# Altium schematic sheet PMK_Sch.SchDoc(PMK_Sch)
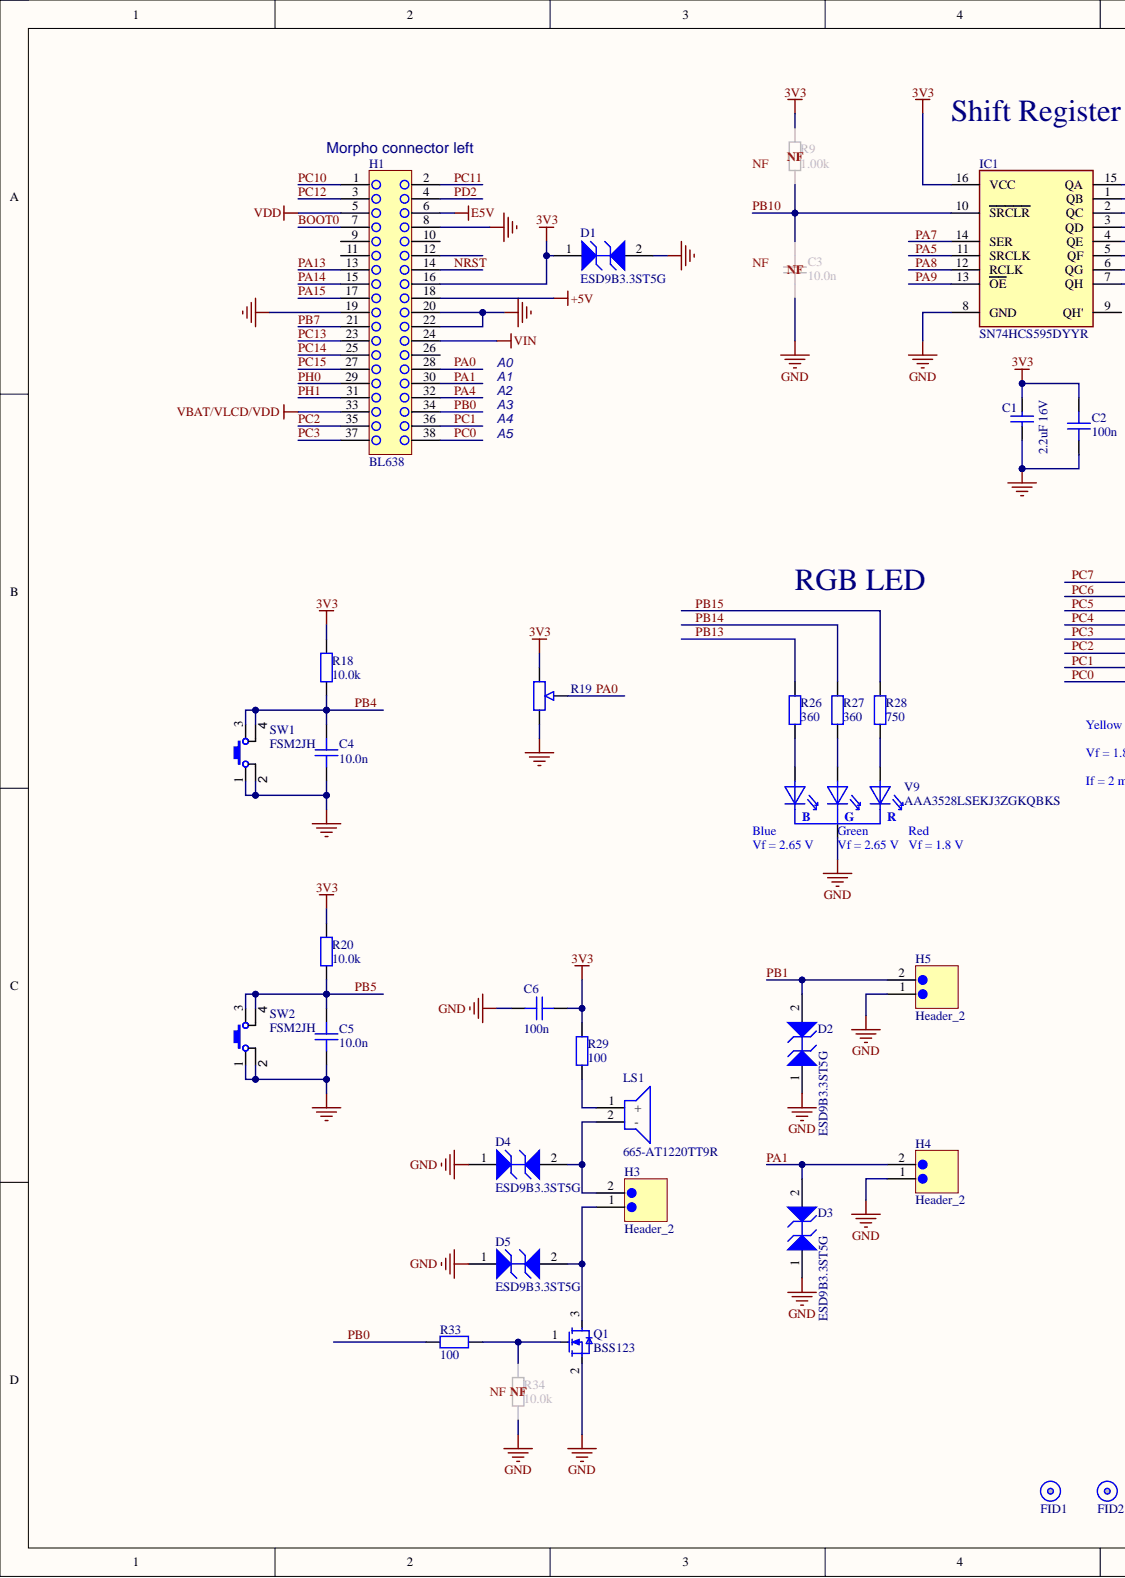
[diagram p0: PMK_Sch.SchDoc, left]
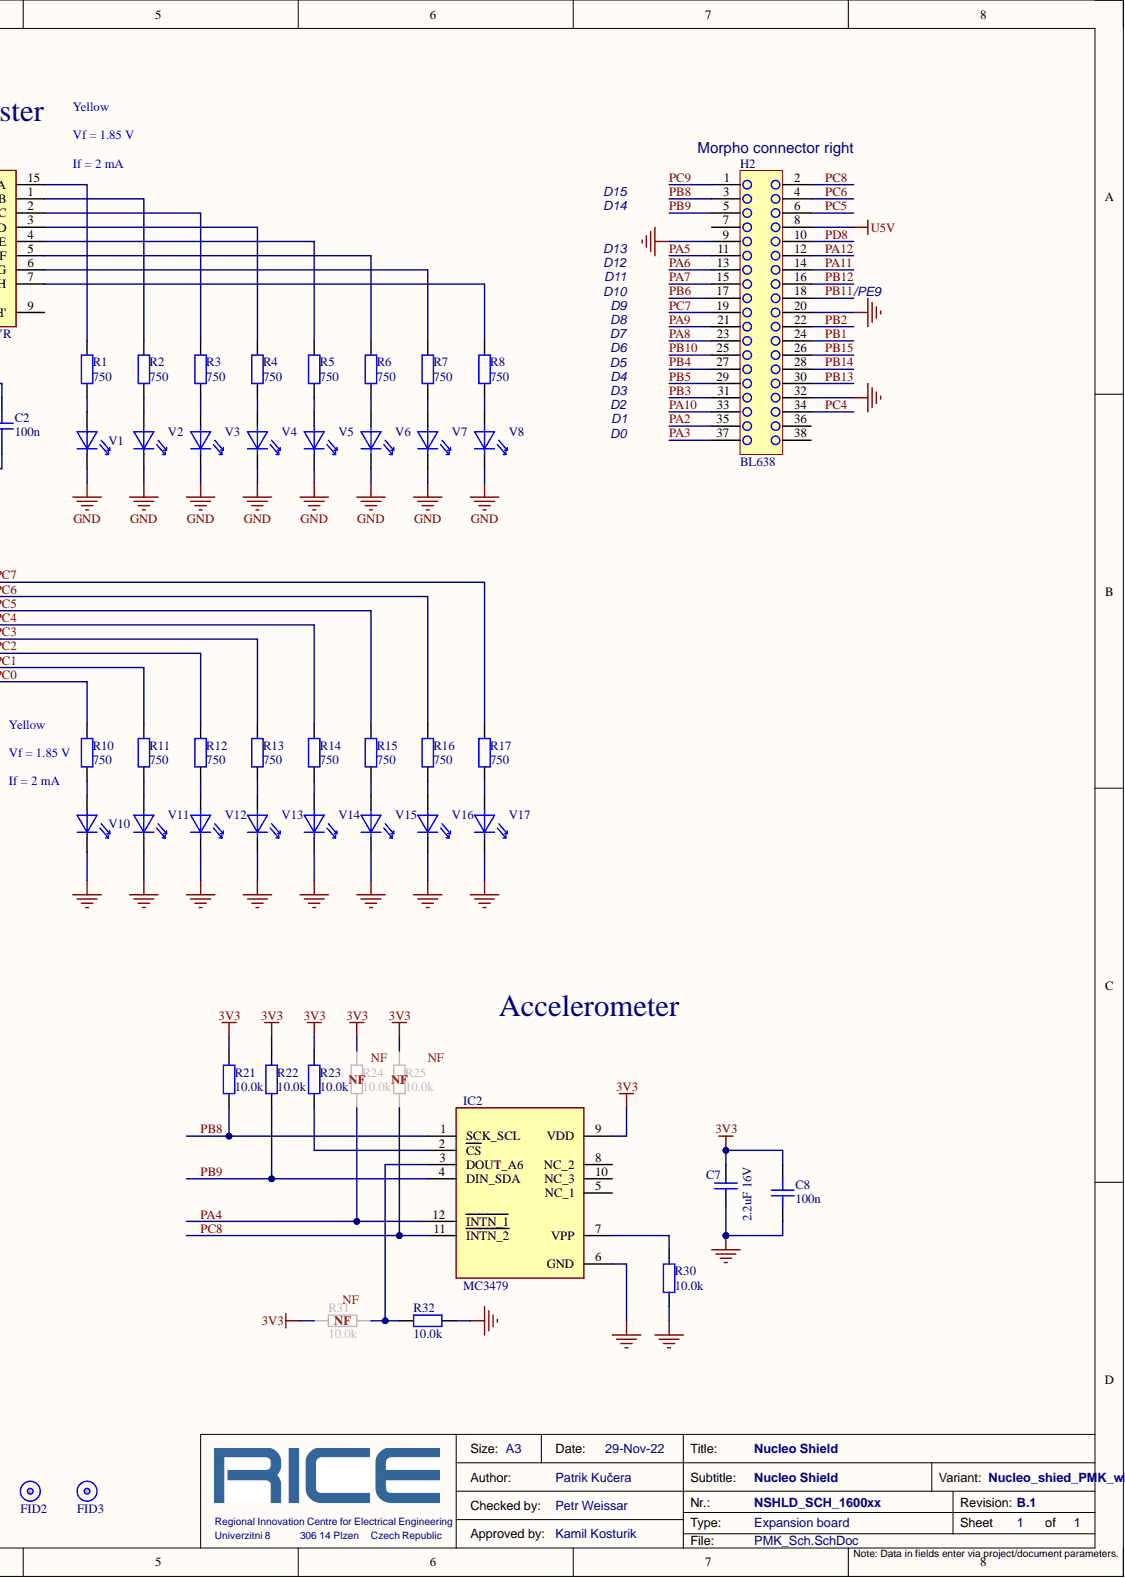
[diagram p1: PMK_Sch.SchDoc, right]

## Parts
C1  2.2uF 16V  [CAPC2013X135X50ML10T20]
C2  100n  [sm_cap_0805]
C3  10.0n  [sm_cap_0805]
C4  10.0n  [sm_cap_0805]
C5  10.0n  [sm_cap_0805]
C6  100n  [sm_cap_0402]
C7  2.2uF 16V  [CAPC2013X135X50ML10T20]
C8  100n  [sm_cap_0805]
D1  ESD9B3.3ST5G  [ONSC-SOD-923-2-514AB-01_V]
D2  ESD9B3.3ST5G  [ONSC-SOD-923-2-514AB-01_V]
D3  ESD9B3.3ST5G  [ONSC-SOD-923-2-514AB-01_V]
D4  ESD9B3.3ST5G  [ONSC-SOD-923-2-514AB-01_V]
D5  ESD9B3.3ST5G  [ONSC-SOD-923-2-514AB-01_V]
FID1  Fiducial  [SM_mch_FIDUCIAL]  (value not drawn)
FID2  Fiducial  [SM_mch_FIDUCIAL]  (value not drawn)
FID3  Fiducial  [SM_mch_FIDUCIAL]  (value not drawn)
H1  BL638  [th_con_rec2x19_pit100]
H2  BL638  [th_con_rec2x19_pit100]
H3  Header_2  [th_con_HDR1X2_pit100]
H4  Header_2  [th_con_HDR1X2_pit100]
H5  Header_2  [th_con_HDR1X2_pit100]
IC1  SN74HCS595DYYR  [SOP65P640X120-16N]
IC2  MC3479  [MC3479]
LS1  AT1220TT9R  Supplier Part Number 1: 665-AT1220TT9R  [AT1220TT9R]
Q1  BSS123  [SM_TRA_SOT23]
R1  750  [sm_res_0805]
R2  750  [sm_res_0805]
R3  750  [sm_res_0805]
R4  750  [sm_res_0805]
R5  750  [sm_res_0805]
R6  750  [sm_res_0805]
R7  750  [sm_res_0805]
R8  750  [sm_res_0805]
R9  1.00k  [sm_res_0805]
R10  750  [sm_res_0805]
R11  750  [sm_res_0805]
R12  750  [sm_res_0805]
R13  750  [sm_res_0805]
R14  750  [sm_res_0805]
R15  750  [sm_res_0805]
R16  750  [sm_res_0805]
R17  750  [sm_res_0805]
R18  10.0k  [sm_res_0805]
R19  10K  [3386F1103TLF]  (value not drawn)
R20  10.0k  [sm_res_0805]
R21  10.0k  [sm_res_0805]
R22  10.0k  [sm_res_0805]
R23  10.0k  [sm_res_0805]
R24  10.0k  [sm_res_0805]
R25  10.0k  [sm_res_0805]
R26  360  [sm_res_0805]
R27  360  [sm_res_0805]
R28  750  [sm_res_0805]
R29  100  [sm_res_0805]
R30  10.0k  [sm_res_0805]
R31  10.0k  [sm_res_0805]
R32  10.0k  [sm_res_0805]
R33  100  [sm_res_0805]
R34  10.0k  [sm_res_0805]
SW1  FSM2JH  [th_swi_lph]
SW2  FSM2JH  [th_swi_lph]
V1  APT2012LSYCK/J3-PRV  [sm_opt_led0805]  (value not drawn)
V2  APT2012LSYCK/J3-PRV  [sm_opt_led0805]  (value not drawn)
V3  APT2012LSYCK/J3-PRV  [sm_opt_led0805]  (value not drawn)
V4  APT2012LSYCK/J3-PRV  [sm_opt_led0805]  (value not drawn)
V5  APT2012LSYCK/J3-PRV  [sm_opt_led0805]  (value not drawn)
V6  APT2012LSYCK/J3-PRV  [sm_opt_led0805]  (value not drawn)
V7  APT2012LSYCK/J3-PRV  [sm_opt_led0805]  (value not drawn)
V8  APT2012LSYCK/J3-PRV  [sm_opt_led0805]  (value not drawn)
V9  AAA3528LSEKJ3ZGKQBKS  [AAA3528LSEKJ3ZGKQBKS-MFG]
V10  APT2012LSYCK/J3-PRV  [sm_opt_led0805]  (value not drawn)
V11  APT2012LSYCK/J3-PRV  [sm_opt_led0805]  (value not drawn)
V12  APT2012LSYCK/J3-PRV  [sm_opt_led0805]  (value not drawn)
V13  APT2012LSYCK/J3-PRV  [sm_opt_led0805]  (value not drawn)
V14  APT2012LSYCK/J3-PRV  [sm_opt_led0805]  (value not drawn)
V15  APT2012LSYCK/J3-PRV  [sm_opt_led0805]  (value not drawn)
V16  APT2012LSYCK/J3-PRV  [sm_opt_led0805]  (value not drawn)
V17  APT2012LSYCK/J3-PRV  [sm_opt_led0805]  (value not drawn)

## Nets
3V3: C1.2 C2.2 C6.2 C7.2 C8.2 D1.1 H1.16 IC1.16(VCC) IC2.9(VDD) R9.1 R18.2 R19.3 R20.2 R21.2 R22.2 R23.2 R24.2 R25.2 R29.2 R31.2
+5V: H1.18
E5V: H1.6
GND: C1.1 C2.1 C3.1 C4.1 C5.1 C6.1 C7.1 C8.1 D1.2 D2.1 D3.1 D4.1 D5.1 H1.8 H1.19 H1.20 H1.22 H2.9 H2.20 H2.32 H4.1 H5.1 IC1.8(GND) IC2.6(GND) Q1.2 R19.1 R30.1 R32.1 R34.1 SW1.1 SW1.2 SW2.1 SW2.2 V1.2 V2.2 V3.2 V4.2 V5.2 V6.2 V7.2 V8.2 V9.2 V10.2 V11.2 V12.2 V13.2 V14.2 V15.2 V16.2 V17.2
U5V: H2.8
VBAT/VLCD/VDD: H1.33
VDD: H1.5
VIN: H1.24
BOOT0: H1.7
NRST: H1.14
PA0: H1.28 R19.2
PA1: D3.2 H1.30 H4.2
PA2: H2.35
PA3: H2.37
PA4: H1.32 IC2.12(INTN_1) R24.1
PA5: H2.11 IC1.11(SRCLK)
PA6: H2.13
PA7: H2.15 IC1.14(SER)
PA8: H2.23 IC1.12(RCLK)
PA9: H2.21 IC1.13(OE)
PA10: H2.33
PA11: H2.14
PA12: H2.12
PA13: H1.13
PA14: H1.15
PA15: H1.17
PB0: H1.34 R33.2
PB1: D2.2 H2.24 H5.2
PB2: H2.22
PB3: H2.31
PB4: C4.2 H2.27 R18.1 SW1.3 SW1.4
PB5: C5.2 H2.29 R20.1 SW2.3 SW2.4
PB6: H2.17
PB7: H1.21
PB8: H2.3 IC2.1(SCK_SCL) R21.1
PB9: H2.5 IC2.4(DIN_SDA) R22.1
PB10: C3.2 H2.25 IC1.10(SRCLR) R9.2
PB11: H2.18
PB12: H2.16
PB13: H2.30 R26.1
PB14: H2.28 R27.1
PB15: H2.26 R28.1
PC0: H1.38 R10.2
PC1: H1.36 R11.2
PC2: H1.35 R12.2
PC3: H1.37 R13.2
PC4: H2.34 R14.2
PC5: H2.6 R15.2
PC6: H2.4 R16.2
PC7: H2.19 R17.2
PC8: H2.2 IC2.11(INTN_2) R25.1
PC9: H2.1
PC10: H1.1
PC11: H1.2
PC12: H1.3
PC13: H1.23
PC14: H1.25
PC15: H1.27
PD2: H1.4
PD8: H2.10
PH0: H1.29
PH1: H1.31
NetD4_2: D4.2 H3.2 LS1.2
NetD5_2: D5.2 H3.1 Q1.3
NetIC1_1: IC1.1(QB) R2.2
NetIC1_2: IC1.2(QC) R3.2
NetIC1_3: IC1.3(QD) R4.2
NetIC1_4: IC1.4(QE) R5.2
NetIC1_5: IC1.5(QF) R6.2
NetIC1_6: IC1.6(QG) R7.2
NetIC1_7: IC1.7(QH) R8.2
NetIC1_15: IC1.15(QA) R1.2
NetIC2_2: IC2.2(CS) R23.1
NetIC2_3: IC2.3(DOUT_A6) R31.1 R32.2
NetIC2_7: IC2.7(VPP) R30.2
NetLS1_1: LS1.1 R29.1
NetQ1_1: Q1.1 R33.1 R34.2
NetR1_1: R1.1 V1.1
NetR2_1: R2.1 V2.1
NetR3_1: R3.1 V3.1
NetR4_1: R4.1 V4.1
NetR5_1: R5.1 V5.1
NetR6_1: R6.1 V6.1
NetR7_1: R7.1 V7.1
NetR8_1: R8.1 V8.1
NetR10_1: R10.1 V10.1
NetR11_1: R11.1 V11.1
NetR12_1: R12.1 V12.1
NetR13_1: R13.1 V13.1
NetR14_1: R14.1 V14.1
NetR15_1: R15.1 V15.1
NetR16_1: R16.1 V16.1
NetR17_1: R17.1 V17.1
NetR26_2: R26.2 V9.4
NetR27_2: R27.2 V9.3
NetR28_2: R28.2 V9.1

Not connected: H1 9 10 11 12 26; H2 7 36 38; IC1 9(QH'); IC2 5(NC_1) 8(NC_2) 10(NC_3)

## Library data of the parts
C1 C7 = CMP-2007-03455-1: Manufacturer: Murata; Part Number: GRM21BR71C225KA12L; Component Kind: Standard; Library Name: Altium Content Vault
C2 C8 = CAP_000228: CAP CER 100n 50V X7R 10% 0805; Manuf Part Number: 08055C104KAT2A; Manufacturer: AVX; Part Category: Ceramic/0805/X7R; Part Select: SCAP0001000400026100; Supp Part number: 478-1395-2-ND; Supp Part number 2: 581-08055C104K; Active: 1; Cat1: Ceramic; Cat2: 0805; Database Table Name: _Capacitors; Designer: Tomáš Košan; Exact price 2: 0; FIT: 0.100000001490116; ID: 232; LasrRevisionNote: new release; Max Oper Temperature: 125; Min Oper Temperature: -55; PCB Status: Electrical; Alternative: 1; Cat3: X7R; Component Kind: Standard; ComponentLink1Description: Datasheet; ComponentLink1URL: https://riceproject.fel.zcu.cz/Altium/PDF/passive/capacitors/xxxxC_AVX.pdf; Dielectric: X7R; Exact price: 1; Exact price 3: 0; LastRevisionDate: 11.5.2011; Library Name: Lib_Components_SQL.DbLib; ManufacturerID: AVX; ManufacturerURL: http://www.avx.com/; Part Number: CAP_000228; Part type: CAP; PartNumber: CAP_000228; PartQuantity: 1; PCB3D: sm_cap_0805; Preference: 0; Price: 0.121100001037121; Price 2: 2.4300000667572; Price 3: 0; Publishied: 11.5.2011; Quantity: 1; RoHS: 1; Simulation: cap; Stock: 166; Supplier: Digikey; Supplier2: Mouser Electronics; Supplier3: N/A; SupplierID: Digikey; SupplierID2: Mouser; SupplierID3: no supplier; SupplierURL: http://www.digikey.com/; SupplierURL2: http://www.mouser.com; SupplierURL3: N/A; Tolerance: 10%; Value: 100n; Voltage: 50V; Publisher: Libor Polacek
C3-C5 = CAP_000175: CAP CER 10.0n 16V X7R 10% 0805; Manuf Part Number: 0805YC103KAT2A; Manufacturer: AVX; Part Category: Ceramic/0805/X7R; Part Select: SCAP0001000400025100; Supp Part number: 0805YC103KAT2A-ND; Active: 1; Cat1: Ceramic; Cat2: 0805; Database Table Name: _Capacitors; Designer: MaJa; FIT: 0.100000001490116; ID: 179; LasrRevisionNote: new release; Max Oper Temperature: 125; Min Oper Temperature: -55; PCB Status: Electrical; Alternative: 1; Cat3: X7R; Component Kind: Standard; ComponentLink1Description: Datasheet; ComponentLink1URL: https://riceproject.fel.zcu.cz/Altium/PDF/passive/capacitors/xxxxC_AVX.pdf; Dielectric: X7R; Exact price: 1; LastRevisionDate: 11.5.2011; Library Name: Lib_Components_SQL.DbLib; ManufacturerID: AVX; ManufacturerURL: http://www.avx.com/; Part Number: CAP_000175; Part type: CAP; PartNumber: CAP_000175; PartQuantity: 1; PCB3D: sm_cap_0805; Price: 0.640100002288818; Price 2: 0; Price 3: 0; Publishied: 11.5.2011; Quantity: 1; RoHS: 1; Simulation: cap; Stock: 16; Supplier: Digikey; Supplier2: N/A; Supplier3: N/A; SupplierID: Digikey; SupplierID2: no supplier; SupplierID3: no supplier; SupplierURL: http://www.digikey.com/; SupplierURL2: N/A; SupplierURL3: N/A; Tolerance: 10%; Value: 10.0n; Voltage: 16V; Publisher: Libor Polacek
C6 = CAP_000417: CAP CER 100nF 25V X7R 10% 0402; Manuf Part Number: C1005X7R1E104K; Manufacturer: TDK Corporation; ManufacturerID: TDK; Part Category: Ceramic/0402/X7R; Part Select: SCAP0001000200026100; Supp Part number: 445-7348-1-ND; Active: 1; Cat1: Ceramic; Cat2: 0402; Database Table Name: _Capacitors; Designer: Libor Polacek; Exact price 2: 0; FIT: 0,100000001490116; ID: 2416; LasrRevisionNote: new release; Max Oper Temperature: 125; Min Oper Temperature: -55; PCB Status: Electrical; Preference: 100; Alternative: 1; Cat3: X7R; Component Kind: Standard; ComponentLink1Description: Datasheet; ComponentLink1URL: https://riceproject.fel.zcu.cz/Altium/PDF/passive/capacitors/Cxxxx_TDK.pdf; Dielectric: X7R; Exact price: 1; Exact price 3: 0; LastRevisionDate: 11.5.2011; Library Name: Lib_Components_SQL.DbLib; ManufacturerURL: http://www.tdk.com/; Part Number: CAP_000417; Part type: CAP; PartNumber: CAP_000417; PartQuantity: 1; PCB3D: sm_cap_0402; Price: 0,349999994039536; Price 2: 0; Price 3: 0; Publishied: 11.5.2011; RoHS: 1; Simulation: cap; Stock: 3064; Supplier: Digikey; Supplier2: N/A; Supplier3: N/A; SupplierID: Digikey; SupplierID2: no supplier; SupplierID3: no supplier; SupplierURL: http://www.digikey.com/; SupplierURL2: N/A; SupplierURL3: N/A; Tolerance: 10%; Value: 100n; Voltage: 25V; Publisher: Libor Polacek
D1-D5 = CMP-1063-00120-1: Transient Voltage Suppressor, Micro-Packaged Diode for ESD Protection, 2-Pin SOD-923, Pb-Free, Tape and Reel; Manufacturer: On Semiconductor; Direction: Bidirectional; IR Max (uA): 1; V(BR) Min (V): 5; VRWM Max (V): 3.3; Component Kind: Standard; ComponentLink1Description: Manufacturer URL; ComponentLink1URL: http://www.onsemi.com/; ComponentLink2Description: Datasheet; ComponentLink2URL: http://www.onsemi.cn/pub_link/Collateral/ESD9B-D.PDF; ComponentLink3Description: Package Specification; ComponentLink3URL: http://www.onsemi.com/pub_link/Collateral/514AB.PDF; DatasheetVersion: Rev. 2; Library Name: Altium Content Vault; Mounting Technology: Surface Mount; Number of Lines: 1; PackageDescription: 2-Pin SOD, Body 0.8 x 0.6 mm; PackageReference: SOD-923-2-514AB-01; PackageVersion: Rev. C, 03/2011; Packing: Tape and Reel; PartNumber: ESD9B3.3ST5G; RoHS: Pb-Free
FID1-FID3 = MCH_000004: Fiducial global circle 1mm; Manuf Part Number: x; ManufacturerID: no manufacturer; Part Category: Virtual/Fiducial; Part Select: SMCH0002000200000000; Active: 1; Cat1: Virtual; Database Table Name: _Mechanicals; Designer: Libor Polacek; Exact price: 0; ID: 1091; LastRevisionNote: new release; Alternative: 1; Cat2: Fiducial; Component Kind: Standard; ComponentLink1Description: Datasheet; FIT: 0; Function Type: fiducial; LastRevisionDate: 8.9.2011; Library Name: Lib_Components_SQL.DbLib; Max Oper Temperature: 0; Min Oper Temperature: 0; Part Number: MCH_000004; Part type: MCH; PartNumber: MCH_000004; PartQuantity: 1; PCB3D: sm_vir_fiducial; PCB Status: Virtual; Preference: 0; Price: 0; Price 2: 0; Price 3: 0; Publishied: 8.9.2011; Quantity: 1; RoHS: 1; Simulation: nosim; Stock: 0; Supp Part number: x; Supplier: N/A; Supplier2: N/A; Supplier3: N/A; SupplierID: no supplier; SupplierID2: no supplier; SupplierID3: no supplier; SupplierURL: N/A; SupplierURL2: N/A; SupplierURL3: N/A; Value: Fiducial; Publisher: Libor Polacek
H1 H2 = CON_000292: CON Receptacle 2x19 100 mil; Manufacturer: Fischer Elektronik; ManufacturerID: Fischer; Part Category: Pin rows/Receptacle; Part Select: TCON0001000200002400; Active: 1; Cat1: Pin rows; Database Table Name: _Connectors; Design: RECEPTACLE 100mil; Designer: Lukas Pusman; Exact price: 0; FIT: 10; ID: 4180; Max Oper Temperature: 125; Min Oper Temperature: -65; PCB Status: Electrical; Alternative: 1; Cat2: Receptacle; Component Kind: Standard; ComponentLink1Description: Datasheet; ComponentLink1URL: https://riceproject.fel.zcu.cz/Altium/PDF/Passive/Connectors/f.con.e.pdf#page=63; Exact price 2: 0; Exact price 3: 0; LatestRevisionDate: 09-Sep-2011; LatestRevisionNote: Re-released for DXP Platform.; Library Name: Lib_Components_SQL.DbLib; Manuf Part Number: BL638; ManufacturerURL: http://www.fischerelektronik.de/index.php/home_en/?&L=1; Part Number: CON_000292; Part type: CON; PartNumber: CON_000292; PartQuantity: 1; Pin: 38; Preference: 0; Price: 10; Price 2: 0; Price 3: 0; Published: 15.6.2011; RoHS: 1; Signal Integrity: Connector; Simulation: nosim; Stock: 0; Supp Part number: BL638; Supplier: Fischer Elektronik; Supplier2: N/A; Supplier3: N/A; SupplierID: Fischer; SupplierID2: no supplier; SupplierID3: no supplier; SupplierURL: http://www.fischerelektronik.de/index.php/home_en/?&L=1; SupplierURL2: N/A; SupplierURL3: N/A; Value: BL638; Publisher: Altium Limited
H3-H5 = CON_000006: CON 2pin header 100mill 5,4mm; Manuf Part Number: SL1111202G; Manufacturer: Fischer Elektronik; ManufacturerID: Fischer; Part Category: Pin rows/Header PIT100/Position; Part Select: TCON0001000500011200; Active: 1; Cat1: Pin rows; Cat2: Header PIT100; Cat3: Position; Database Table Name: _Connectors; Designer: Jiri  Cengery; Exact price: 0; FIT: 2; ID: 901; LastRevisionNote: Initial version; Max Oper Temperature: 125; Min Oper Temperature: -65; PCB Status: Electrical; Alternative: 1; Component Kind: Standard; ComponentLink1Description: Datasheet; ComponentLink1URL: https://riceproject.fel.zcu.cz/Altium/PDF/Passive/Connectors/f.con.e.pdf#page=40; Design: HEADER 100mill; Exact price 2: 0; Exact price 3: 0; LastRevisionDate: 15.6.2011; Library Name: Lib_Components_SQL.DbLib; ManufacturerURL: http://www.fischerelektronik.de/index.php/home_en/?&L=1; Part Number: CON_000006; Part type: CON; PartNumber: CON_000006; PartQuantity: 1; PCB3D: th_con_HDR1X2; Pin: 2; Preference: 0; Price: 0; Price 2: 0; Price 3: 0; Published: 15.6.2011; RoHS: 1; Signal Integrity: Connector; Simulation: nosim; Stock: 21; Supp Part number: SL1111202G; Supplier: Fischer Elektronik; Supplier2: N/A; Supplier3: N/A; SupplierID: Fischer; SupplierID2: no supplier; SupplierID3: no supplier; SupplierURL: http://www.fischerelektronik.de/index.php/home_en/?&L=1; SupplierURL2: N/A; SupplierURL3: N/A; Value: Header_2; Publisher: Turjanica
IC1 = SN74HCS595DYYR: 8-bit shift register with Schmitt-trigger inputs and 3-state output registers 16-SOT-23-THIN -40 to 125; Manufacturer: Texas Instruments; Component Kind: Standard; Manufacturer Part Number: SN74HCS595DYYR; Pricing 1: 1=0.57000, 10=0.48600, 50=0.48600, 100=0.33700, 1000=0.22000, 10000=0.18700 (USD); RoHS: No; Stock 1: 3000; Supplier 1: Mouser; Supplier Part Number 1: 595-SN74HCS595DYYR; Library Name: PMK_Schlib.SchLib
IC2 = MC3479: Akcelerometry Small Digital 3-axis; Manufacturer: Memsic; Component Kind: Standard; Manufacturer Part Number: MC3479; Pricing 1: 1=1.89000, 10=1.54000, 50=1.15000, 100=1.04000, 1000=0.77800, 10000=0.66000 (USD); RoHS: Yes; Stock 1: 483746; Supplier 1: Mouser; Supplier Part Number 1: 498-MC3479; Library Name: PMK_Schlib.SchLib
LS1 = AT-1220-TT-9-R: Audio Indicator Transducer Electromagnetic 3.5Vp-p 50mA Pin 3Vp-p 1548Hz to 2548Hz Through Hole; Manufacturer: Projects Unlimited; Frequency: 2.048kHz; Operating Supply Current: 35mA; Voltage Rating: 3.5V; Category: Audio Products; Component Kind: Standard; ComponentLink1Description: http://www.mouser.com/ds/2/334/AT-1220-TT-9-R-532252.pdf?src-supplier=Mouser; ComponentLink1URL: http://www.mouser.com/ds/2/334/AT-1220-TT-9-R-532252.pdf?src-supplier=Mouser; ComponentLink2Description: http://www.puiaudio.com/pdf/AT-1220-TT-9-R.pdf?src-supplier=Digi-Key; ComponentLink2URL: http://www.puiaudio.com/pdf/AT-1220-TT-9-R.pdf?src-supplier=Digi-Key; ComponentLink3Description: http://www.puiaudio.com/pdf/AT-1220-TT-9-R.pdf?src-supplier=Avnet; ComponentLink3URL: http://www.puiaudio.com/pdf/AT-1220-TT-9-R.pdf?src-supplier=Avnet; Contact Plating: Gold; Diameter: 12mm; Height: 8.5mm; Manufacturer Part Number: AT-1220-TT-9-R; Max Operating Temperature: 70°C; Max Supply Current: 50mA; Min Operating Temperature: -20°C; Mount: Through Hole; Packaging: Tray; Pricing 1: 1=0.78000, 10=0.65500, 50=0.57600, 100=0.54300, 1000=0.41300, 10000=0.39700 (USD); RoHS: Yes; Sensing Method: Magnetic; Stock 1: 2014; Supplier 1: Mouser; Termination: PC Pins; Library Name: PMK_Schlib.SchLib
Q1 = TRA_000033: TRA MOSFET N-Channel, 100V, 170mA, 6 Ohm @ 170mA Vgs 10V,  SOT23; Manufacturer: Fairchild; Part Category: Unipolar/NMOS; Part Select: STRA0001000100000000; Supp Part number: BSS123NCT-ND; Active: 1; Cat1: Unipolar; Cat2: NMOS; Database Table Name: _Transistors; Designer: Kamil Kosturik; Exact price 2: 0; ID: 1995; LastRevisionNote: new release; Max Oper Temperature: 150; Min Oper Temperature: -55; ON resistance: 6Ohm; PCB Status: Electrical; Signal Integrity Path: nosim; Alternative: 1; Component Kind: Standard; ComponentLink1Description: Datasheet; ComponentLink1URL: https://riceproject.fel.zcu.cz/Altium/PDF/Semiconductor\Analog\Transistor\NMOS\BSS123.pdf; Current: 170mA; Exact price: 1; Exact price 3: 0; FIT: 1; LastRevisionDate: 11.5.2011; Library Name: Lib_Components_SQL.DbLib; Manuf Part Number: BSS123; ManufacturerID: Fairchild; ManufacturerURL: http://www.fairchildsemi.com/; Part Number: TRA_000033; Part type: TRA; PartNumber: TRA_000033; PartQuantity: 1; Preference: 0; Price: 5,5; Price 2: 0; Price 3: 0; Published: 11.5.2011; RoHS: 1; Simulation: nosim; Stock: 80; Supplier: Digikey; Supplier2: N/A; Supplier3: N/A; SupplierID: Digikey; SupplierID2: no supplier; SupplierID3: no supplier; SupplierURL: http://www.digikey.com/; SupplierURL2: N/A; SupplierURL3: N/A; Value: BSS123; Voltage: 100V; Publisher: Libor Polacek
R1-R8 R10-R17 R28 = RES_000240: RES 0805 750R 1% 100ppm SMD thick film; Manuf Part Number: ERJ6ENF7500V; Manufacturer: Panasonic; Part Category: Thick film/0805; Part Select: SRES0001000400003750; Supp Part number: P750CTR-ND; Active: 1; Cat2: 0805; Database Table Name: _Resistors; Designer: Kamil Kosturik; Exact price 2: 0; FIT: 0.100000001490116; ID: 601; LastRevisionNote: new release; Max Oper Temperature: 125; Min Oper Temperature: -55; PCB Status: Electrical; Power: 125mW; Alternative: 1; Cat1: Thick film; Component Kind: Standard; ComponentLink1Description: Datasheet; ComponentLink1URL: https://riceproject.fel.zcu.cz/Altium/PDF/passive/resistors/ERJ_EKF_Panasonic.pdf; Exact price: 1; Exact price 3: 0; LastRevisionDate: 11.5.2011; Library Name: Lib_Components_SQL.DbLib; ManufacturerID: Panasonic; ManufacturerURL: http://www.industrial.panasonic.com/; Part Number: RES_000240; Part type: RES; PartNumber: RES_000240; PartQuantity: 1; PCB3D: sm_res_0805; Preference: 0; Price: 0.0900000035762787; Price 2: 0; Price 3: 0; Published: 11.5.2011; Quantity: 1; RoHS: 1; Simulation: res; Stock: 0; Supplier: Digikey; Supplier2: N/A; Supplier3: N/A; SupplierID: Digikey; SupplierID2: no supplier; SupplierID3: no supplier; SupplierURL: http://www.digikey.com/; SupplierURL2: N/A; SupplierURL3: N/A; Thermal Stability: 100ppm; Tolerance: 1%; Value: 750; Publisher: Libor Polacek
R9 = RES_000243: RES 0805 1.00k 1% 100ppm SMD thick film; Manuf Part Number: ERJ6ENF1001V; Manufacturer: Panasonic; Part Category: Thick film/0805; Part Select: SRES0001000400004100; Supp Part number: P1.00kCTR-ND; Supp Part number 2: 667-ERJ-6ENF1001V; Active: 1; Cat2: 0805; Database Table Name: _Resistors; Designer: Tomáš Košan; Exact price 2: 0; FIT: 0.100000001490116; ID: 604; LastRevisionNote: new release; Max Oper Temperature: 125; Min Oper Temperature: -55; PCB Status: Electrical; Power: 125mW; Alternative: 1; Cat1: Thick film; Component Kind: Standard; ComponentLink1Description: Datasheet; ComponentLink1URL: https://riceproject.fel.zcu.cz/Altium/PDF/passive/resistors/ERJ_EKF_Panasonic.pdf; Exact price: 1; Exact price 3: 0; LastRevisionDate: 11.5.2011; Library Name: Lib_Components_SQL.DbLib; ManufacturerID: Panasonic; ManufacturerURL: http://www.industrial.panasonic.com/; Part Number: RES_000243; Part type: RES; PartNumber: RES_000243; PartQuantity: 1; PCB3D: sm_res_0805; Preference: 0; Price: 0.0900000035762787; Price 2: 2.4300000667572; Price 3: 0; Published: 11.5.2011; Quantity: 1; RoHS: 1; Simulation: res; Stock: 1025; Supplier: Digikey; Supplier2: Mouser Electronics; Supplier3: N/A; SupplierID: Digikey; SupplierID2: Mouser; SupplierID3: no supplier; SupplierURL: http://www.digikey.com/; SupplierURL2: http://www.mouser.com; SupplierURL3: N/A; Thermal Stability: 100ppm; Tolerance: 1%; Value: 1.00k; Publisher: Libor Polacek
R18 R20-R25 R30-R32 R34 = RES_000267: RES 0805 10.0k 1% 100ppm SMD thick film; Manuf Part Number: ERJ6ENF1002V; Manufacturer: Panasonic; Part Category: Thick film/0805; Part Select: SRES0001000400005100; Supp Part number: P10.0kCTR-ND; Supp Part number 2: 667-ERJ-6ENF1002V; Active: 1; Cat2: 0805; Database Table Name: _Resistors; Designer: Tomáš Košan; Exact price 2: 0; FIT: 0.100000001490116; ID: 628; LastRevisionNote: new release; Max Oper Temperature: 125; Min Oper Temperature: -55; PCB Status: Electrical; Power: 125mW; Alternative: 1; Cat1: Thick film; Component Kind: Standard; ComponentLink1Description: Datasheet; ComponentLink1URL: https://riceproject.fel.zcu.cz/Altium/PDF/passive/resistors/ERJ_EKF_Panasonic.pdf; Exact price: 1; Exact price 3: 0; LastRevisionDate: 11.5.2011; Library Name: Lib_Components_SQL.DbLib; ManufacturerID: Panasonic; ManufacturerURL: http://www.industrial.panasonic.com/; Part Number: RES_000267; Part type: RES; PartNumber: RES_000267; PartQuantity: 1; PCB3D: sm_res_0805; Preference: 0; Price: 0.0900000035762787; Price 2: 2.4300000667572; Price 3: 0; Published: 11.5.2011; Quantity: 1; RoHS: 1; Simulation: res; Stock: 4990; Supplier: Digikey; Supplier2: Mouser Electronics; Supplier3: N/A; SupplierID: Digikey; SupplierID2: Mouser; SupplierID3: no supplier; SupplierURL: http://www.digikey.com/; SupplierURL2: http://www.mouser.com; SupplierURL3: N/A; Thermal Stability: 100ppm; Tolerance: 1%; Value: 10.0k; Publisher: Libor Polacek
R19 = 3386F-1-103TLF: Res Cermet Trimmer 10K Ohm 10% 1/2W 1(Elec)/1(Mech)Turn 8.38mm (9.53 X 9.53 X 10.41mm) Pin Thru-Hole Tube; Manufacturer: Bourns; Max Power Dissipation: 500mW; Number of Turns: 1; Resistance: 10kR; Temperature Coefficient: 100ppm/°C; Voltage Rating: 300V; Category: Variable Resistors and Potentiometers; Color: Blue; Component Kind: Standard; ComponentLink1Description: https://datasheet.ciiva.com/11831/0900766b8069ccf9-11831221.pdf?src-supplier=RSComponents; ComponentLink1URL: https://datasheet.ciiva.com/11831/0900766b8069ccf9-11831221.pdf?src-supplier=RSComponents; ComponentLink2Description: https://datasheet.ciiva.com/29122/447357-da-01-en-trimmer-tht3386f-1-103tlf-10k-0-5w-29122237.pdf?src-supplier=Conrad; ComponentLink2URL: https://datasheet.ciiva.com/29122/447357-da-01-en-trimmer-tht3386f-1-103tlf-10k-0-5w-29122237.pdf?src-supplier=Conrad; ComponentLink3Description: https://datasheet.ciiva.com/8701/3386-8701593.pdf?src-supplier=Avnet; ComponentLink3URL: https://datasheet.ciiva.com/8701/3386-8701593.pdf?src-supplier=Avnet; ComponentLink4Description: https://datasheet.ciiva.com/15156/getdatasheetpartid-239796-15156892.pdf?src-supplier=Verical; ComponentLink4URL: https://datasheet.ciiva.com/15156/getdatasheetpartid-239796-15156892.pdf?src-supplier=Verical; ComponentLink5Description: https://datasheet.ciiva.com/2156/3386-2156919.pdf?src-supplier=Digi-Key; ComponentLink5URL: https://datasheet.ciiva.com/2156/3386-2156919.pdf?src-supplier=Digi-Key; ComponentLink6Description: https://datasheet.ciiva.com/7953/1815119-7953071.pdf?src-supplier=Element14; ComponentLink6URL: https://datasheet.ciiva.com/7953/1815119-7953071.pdf?src-supplier=Element14; Depth: 9.53mm; Height: 0.19inch; Lead Free: Lead Free; Length: 9.53mm; Manufacturer Part Number: 3386F-1-103TLF; Max Operating Temperature: 125°C; Military Standard: Not; Min Operating Temperature: -55°C; Mount: Through Hole; Package Quantity: 100; Packaging: Rail/Tube; Power Rating: 500mW; Pricing 1: 1=2.49000, 10=2.19000, 50=2.19000, 100=1.81000, 1000=1.36000, 10000=1.13000 (USD); Radiation Hardening: No; Reach SVHC Compliant: Yes; Resistance Tolerance: 10%; Resistor Type: Cermet; RoHS: Yes; RoHS Compliant: Yes; Series: Trimpot® 3386 - Sealed; Stock 1: 561; Supplier 1: Mouser; Supplier Part Number 1: 652-3386F-1-103TLF; Taper: Linear; Technology: CERMET; Termination: Pin; Tolerance: 10%; Weight: 0.03oz; Width: 9.53mm; Library Name: PMK_Schlib.SchLib
R26 R27 = RES_000232: RES 0805 360R 1% 100ppm SMD thick film; Manuf Part Number: ERJ6ENF3600V; Manufacturer: Panasonic; Part Category: Thick film/0805; Part Select: SRES0001000400003360; Supp Part number: P360CTR-ND; Active: 1; Cat2: 0805; Database Table Name: _Resistors; Designer: Kamil Kosturik; Exact price 2: 0; FIT: 0.100000001490116; ID: 593; LastRevisionNote: new release; Max Oper Temperature: 125; Min Oper Temperature: -55; PCB Status: Electrical; Power: 125mW; Alternative: 1; Cat1: Thick film; Component Kind: Standard; ComponentLink1Description: Datasheet; ComponentLink1URL: https://riceproject.fel.zcu.cz/Altium/PDF/passive/resistors/ERJ_EKF_Panasonic.pdf; Exact price: 1; Exact price 3: 0; LastRevisionDate: 11.5.2011; Library Name: Lib_Components_SQL.DbLib; ManufacturerID: Panasonic; ManufacturerURL: http://www.industrial.panasonic.com/; Part Number: RES_000232; Part type: RES; PartNumber: RES_000232; PartQuantity: 1; PCB3D: sm_res_0805; Preference: 0; Price: 0.0900000035762787; Price 2: 0; Price 3: 0; Published: 11.5.2011; Quantity: 1; RoHS: 1; Simulation: res; Stock: 0; Supplier: Digikey; Supplier2: N/A; Supplier3: N/A; SupplierID: Digikey; SupplierID2: no supplier; SupplierID3: no supplier; SupplierURL: http://www.digikey.com/; SupplierURL2: N/A; SupplierURL3: N/A; Thermal Stability: 100ppm; Tolerance: 1%; Value: 360; Publisher: Libor Polacek
R29 R33 = RES_000219: RES 0805 100R 1% 100ppm SMD thick film; Manuf Part Number: ERJ6ENF1000V; Manufacturer: Panasonic; Part Category: Thick film/0805; Part Select: SRES0001000400003100; Supp Part number: P100CTR-ND; Active: 1; Cat2: 0805; Database Table Name: _Resistors; Designer: Kamil Kosturik; Exact price 2: 0; FIT: 0.100000001490116; ID: 580; LastRevisionNote: new release; Max Oper Temperature: 125; Min Oper Temperature: -55; PCB Status: Electrical; Power: 125mW; Alternative: 1; Cat1: Thick film; Component Kind: Standard; ComponentLink1Description: Datasheet; ComponentLink1URL: https://riceproject.fel.zcu.cz/Altium/PDF/passive/resistors/ERJ_EKF_Panasonic.pdf; Exact price: 1; Exact price 3: 0; LastRevisionDate: 11.5.2011; Library Name: Lib_Components_SQL.DbLib; ManufacturerID: Panasonic; ManufacturerURL: http://www.industrial.panasonic.com/; Part Number: RES_000219; Part type: RES; PartNumber: RES_000219; PartQuantity: 1; PCB3D: sm_res_0805; Preference: 0; Price: 0.0900000035762787; Price 2: 0; Price 3: 0; Published: 11.5.2011; Quantity: 1; RoHS: 1; Simulation: res; Stock: 141; Supplier: Digikey; Supplier2: N/A; Supplier3: N/A; SupplierID: Digikey; SupplierID2: no supplier; SupplierID3: no supplier; SupplierURL: http://www.digikey.com/; SupplierURL2: N/A; SupplierURL3: N/A; Thermal Stability: 100ppm; Tolerance: 1%; Value: 100; Publisher: Libor Polacek
SW1 SW2 = SWI_000006: MCH button switch; Manufacturer: TE connectivity; Part Category: Button/Closing; Part Select: TSWI0002000100000000; Supp Part number: 1555981; Active: 1; Cat2: Closing; Database Table Name: _Switches; Designer: Jiri Cengery; Exact price 2: 0; FIT: 5; ID: 2202; Max Oper Temperature: 85; Min Oper Temperature: -40; PCB Status: Electrical; Alternative: 1; Cat1: Button; Component Kind: Standard; ComponentLink1Description: Datasheet; ComponentLink1URL: https://riceproject.fel.zcu.cz/Altium/PDF/Passive/Switches/typ_LPV.pdf; ComponentLink2URL: https://riceproject.fel.zcu.cz/Altium/PDF/Passive/Switches/TE_SWITCHES_CORE_PROGRAM_CATALOG_0308.pdf; Design: button; Exact price: 1; Exact price 3: 0; LatestRevisionDate: 17-Jul-2002; LatestRevisionNote: Re-released for DXP Platform.; Library Name: Lib_Components_SQL.DbLib; Manuf Part Number: FSM2JH; ManufacturerID: TE connectivity; ManufacturerURL: http://www.te.com/en/home.html; Part Number: SWI_000006; Part type: SWI; PartNumber: SWI_000006; PartQuantity: 1; Preference: 0; Price: 2; Price 2: 0; Price 3: 0; Published: 24-Mar-1999; RoHS: 1; Simulation: nosim; Stock: 0; Supplier: Farnell; Supplier2: N/A; Supplier3: N/A; SupplierID: Farnell; SupplierID2: no supplier; SupplierID3: no supplier; SupplierURL: http://cz.farnell.com/; SupplierURL2: N/A; SupplierURL3: N/A; Value: FSM2JH; Publisher: Altium Limited
V1-V8 V10-V17 = OPT_000082: OPT LED yellow, 2mA, 25mcd; Manufacturer: kingbright; Part Category: LED/0805; Part Select: SOPT0001000200000000; Supp Part number: 754-1937-1-ND; Supp Part number 2: 604-APT2012LSYCKJ3RV; Active: 1; Cat2: 0805; Database Table Name: _Optoelectronics; Designer: Martin Jára; Exact price 2: 0; ID: 6102; LastRevisionNote: new release; Max Oper Temperature: 85; Min Oper Temperature: -40; Alternative: 1; Cat1: LED; Color: yellow; Component Kind: Standard; ComponentLink1Description: Datasheet; ComponentLink1URL: https://riceproject.fel.zcu.cz/Altium/PDF/Semiconductor/Analog/LED/APT2012LSYCK-J3-PRV.pdf; Exact price: 1; Exact price 3: 0; FIT: 0; LastRevisionDate: 11.5.2011; Library Name: Lib_Components_SQL.DbLib; Manuf Part Number: APT2012LSYCK/J3-PRV; ManufacturerID: Kingbright; ManufacturerURL: http://www.kingbright.com; Part Number: OPT_000082; Part type: OPT; PartNumber: OPT_000082; PartQuantity: 1; Preference: 1; Price: 13.2216997146606; Price 2: 10.289999961853; Price 3: 0; Published: 11.5.2011; RoHS: 1; Simulation: nosim; Stock: 0; Supplier: Digikey; Supplier2: Mouser Electronics; Supplier3: N/A; SupplierID: Digikey; SupplierID2: Mouser; SupplierID3: no supplier; SupplierURL: http://www.digikey.com/; SupplierURL2: http://www.mouser.com; SupplierURL3: N/A; Value: APT2012LSYCK/J3-PRV; Publisher: Libor Polacek
V9 = AAA3528LSEKJ3ZGKQBKS: Heli Colour; 3.5X2.8MM Low Current Rgb SMD Led; Manufacturer: Kingbright; Dominant Wavelength: 465nm; Forward Voltage: 2.7V; Lens Color: Colorless; Lens Transparency: Clear; Luminous Intensity: 30mcd; Test Current: 2mA; Viewing Angle: 120°; Case/Package: PLCC; Category: LEDs; Color: Blue,Green,Red; Component Kind: Standard; ComponentLink1Description: http://www.alliedelec.com/m/d/86acaee57a43f2349fd1081fd6c8c8de.pdf?src-supplier=Allied+Electronics; ComponentLink1URL: http://www.alliedelec.com/m/d/86acaee57a43f2349fd1081fd6c8c8de.pdf?src-supplier=Allied+Electronics; ComponentLink2Description: http://www.mouser.com/ds/2/216/AAA3528LSEKJ3ZGKQBKS-786253.pdf?src-supplier=Mouser; ComponentLink2URL: http://www.mouser.com/ds/2/216/AAA3528LSEKJ3ZGKQBKS-786253.pdf?src-supplier=Mouser; ComponentLink3Description: http://www.kingbrightusa.com/images/catalog/SPEC/AAA3528LSEKJ3ZGKQBKS.pdf?src-supplier=Digi-Key; ComponentLink3URL: http://www.kingbrightusa.com/images/catalog/SPEC/AAA3528LSEKJ3ZGKQBKS.pdf?src-supplier=Digi-Key; Height: 2.1mm; Length: 3.2mm; Manufacturer Part Number: AAA3528LSEKJ3ZGKQBKS; Mount: Surface Mount; Packaging: Tape and Reel; Pricing 1: 1=0.85000, 10=0.55900, 50=0.55900, 100=0.36700, 1000=0.28900, 10000=0.26700 (USD); RoHS: Yes; Stock 1: 11670; Supplier 1: Mouser; Supplier Part Number 1: 604-AAA3528LSEKJ3ZGK; Width: 2.8mm; Library Name: PMK_Schlib.SchLib
